# Altium schematic sheet Halloween2021.SchDoc(Halloween2021)
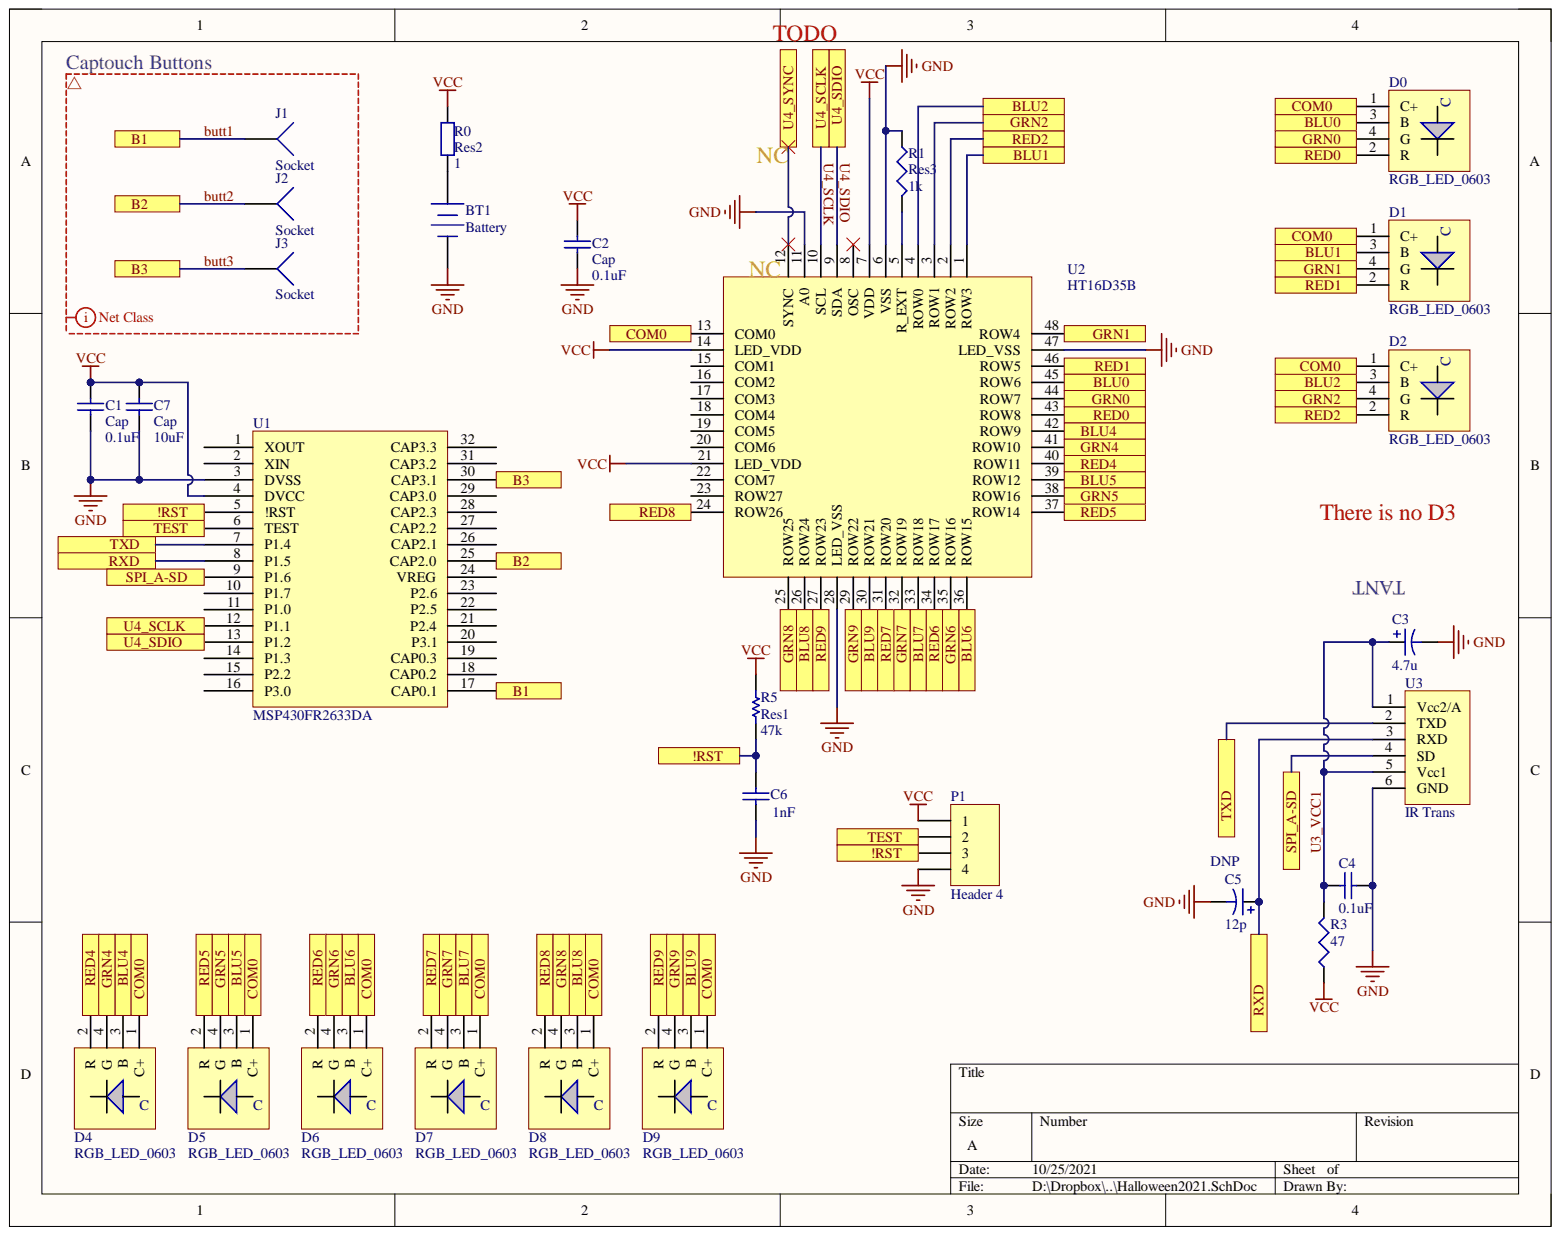
[diagram p0: Halloween2021.SchDoc]

## Parts
BT1  Battery  [Keystone 1050]
C1  Cap  value 0.1uF  [CAPC1608(0603)]
C2  Cap  value 0.1uF  [CAPC1608(0603)]
C3  value 4.7u  [CAPC1608(0603)]  (hidden comment: Cap Pol3)
C4  value 0.1uF  [CAPC1608(0603)]  (hidden comment: Cap)
C5  value 12p  [CAPC1608(0603)]  (hidden comment: Cap Pol3)
C6  value 1nF  [CAPC1608(0603)]
C7  Cap  value 10uF  [CAPC1608(0603)]
D0  RGB_LED_0603  [HQ19-2331BGRC]
D1  RGB_LED_0603  [HQ19-2331BGRC]
D2  RGB_LED_0603  [HQ19-2331BGRC]
D4  RGB_LED_0603  [HQ19-2331BGRC]
D5  RGB_LED_0603  [HQ19-2331BGRC]
D6  RGB_LED_0603  [HQ19-2331BGRC]
D7  RGB_LED_0603  [HQ19-2331BGRC]
D8  RGB_LED_0603  [HQ19-2331BGRC]
D9  RGB_LED_0603  [HQ19-2331BGRC]
J1  Socket  [captouch]
J2  Socket  [captouch]
J3  Socket  [captouch1.5mm]
P1  Header 4  [1x4 2mm Header]
R0  Res2  value 1  [RESC5025(2010)]
R1  Res3  value 1k  [RESC1608(0603)]
R3  value 47  [RESC1608(0603)]  (hidden comment: Res3)
R5  Res1  value 47k  [RESC1608(0603)]
U1  MSP430FR2633DA  [TSOP65P810X120-32N]
U2  HT16D35B  [TSQFP50P900X900X160-48N]
U3  IR Trans  [IR]

## Ports
!RST, B1, B2, B3, BLU0, BLU1, BLU2, BLU4, BLU5, BLU6, BLU7, BLU8, BLU9, COM0, GRN0, GRN1, GRN2, GRN4, GRN5, GRN6, GRN7, GRN8, GRN9, RED0, RED1, RED2, RED4, RED5, RED6, RED7, RED8, RED9, RXD, SPI_A-SD, TEST, TXD, U4_SCLK, U4_SDIO, U4_SYNC

## Nets
GND: BT1.2 C1.2 C2.1 C3.2 C4.2 C5.2 C6.1 C7.1 P1.4 R1.1 U1.3(DVSS) U2.6(VSS) U2.11(A0) U2.28(LED_VSS) U2.47(LED_VSS) U3.6(GND)
VCC: C1.1 C2.2 C7.2 P1.1 R0.2 R3.1 R5.1 U1.4(DVCC) U2.7(VDD) U2.14(LED_VDD) U2.21(LED_VDD)
!RST: C6.2 P1.3 R5.2 U1.5(!RST) ; port !RST
BLU0: D0.3(B) U2.45(ROW6) ; port BLU0
BLU1: D1.3(B) U2.1(ROW3) ; port BLU1
BLU2: D2.3(B) U2.4(ROW0) ; port BLU2
BLU4: D4.3(B) U2.42(ROW9) ; port BLU4
BLU5: D5.3(B) U2.39(ROW12) ; port BLU5
BLU6: D6.3(B) U2.36(ROW15) ; port BLU6
BLU7: D7.3(B) U2.33(ROW18) ; port BLU7
BLU8: D8.3(B) U2.26(ROW24) ; port BLU8
BLU9: D9.3(B) U2.30(ROW21) ; port BLU9
butt1: J1.1 U1.17(CAP0.1) ; port B1
butt2: J2.1 U1.25(CAP2.0) ; port B2
butt3: J3.1 U1.30(CAP3.1) ; port B3
COM0: D0.1(C+) D1.1(C+) D2.1(C+) D4.1(C+) D5.1(C+) D6.1(C+) D7.1(C+) D8.1(C+) D9.1(C+) U2.13(COM0) ; port COM0
GRN0: D0.4(G) U2.44(ROW7) ; port GRN0
GRN1: D1.4(G) U2.48(ROW4) ; port GRN1
GRN2: D2.4(G) U2.3(ROW1) ; port GRN2
GRN4: D4.4(G) U2.41(ROW10) ; port GRN4
GRN5: D5.4(G) U2.38(ROW16) ; port GRN5
GRN6: D6.4(G) U2.35(ROW16) ; port GRN6
GRN7: D7.4(G) U2.32(ROW19) ; port GRN7
GRN8: D8.4(G) U2.25(ROW25) ; port GRN8
GRN9: D9.4(G) U2.29(ROW22) ; port GRN9
RED0: D0.2(R) U2.43(ROW8) ; port RED0
RED1: D1.2(R) U2.46(ROW5) ; port RED1
RED2: D2.2(R) U2.2(ROW2) ; port RED2
RED4: D4.2(R) U2.40(ROW11) ; port RED4
RED5: D5.2(R) U2.37(ROW14) ; port RED5
RED6: D6.2(R) U2.34(ROW17) ; port RED6
RED7: D7.2(R) U2.31(ROW20) ; port RED7
RED8: D8.2(R) U2.24(ROW26) ; port RED8
RED9: D9.2(R) U2.27(ROW23) ; port RED9
RXD: C5.1 U1.8(P1.5) U3.3(RXD) ; port RXD
SPI_A-SD: U1.9(P1.6) U3.4(SD) ; port SPI_A-SD
TEST: P1.2 U1.6(TEST) ; port TEST
TXD: U1.7(P1.4) U3.2(TXD) ; port TXD
U3_VCC1: C3.1 C4.1 R3.2 U3.1(Vcc2/A) U3.5(Vcc1)
U4_SCLK: U1.12(P1.1) U2.10(SCL) ; port U4_SCLK
U4_SDIO: U1.13(P1.2) U2.9(SDA) ; port U4_SDIO
U4_SYNC: U2.12(SYNC) ; port U4_SYNC
NetBT1_1: BT1.1 R0.1
NetR1_2: R1.2 U2.5(R_EXT)

Not connected: U1 1(XOUT) 2(XIN) 10(P1.7) 11(P1.0) 14(P1.3) 15(P2.2) 16(P3.0) 18(CAP0.2) 19(CAP0.3) 20(P3.1) 21(P2.4) 22(P2.5) 23(P2.6) 24(VREG) 26(CAP2.1) 27(CAP2.2) 28(CAP2.3) 29(CAP3.0) 31(CAP3.2) 32(CAP3.3); U2 8(OSC) 15(COM1) 16(COM2) 17(COM3) 18(COM4) 19(COM5) 20(COM6) 22(COM7) 23(ROW27)

## Library data of the parts
BT1 = Battery: Multicell Battery; Component Kind: Standard; LatestRevisionDate: 17-Jul-2002; LatestRevisionNote: Re-released for DXP Platform.; Library Name: Miscellaneous Devices.IntLib; PackageDescription: Battery; 2 Leads; PackageReference: BAT-2; Published: 24-Mar-1999; Publisher: Altium Limited
C1 C2 C4 C6 C7 = Cap: Capacitor; Component Kind: Standard; LatestRevisionDate: 17-Jul-2002; LatestRevisionNote: Re-released for DXP Platform.; Library Name: Miscellaneous Devices.IntLib; PackageDescription: Radial Cap, Thru-Hole; 2 Leads; 0.3 in Pin Spacing; PackageReference: RAD-0.3; Published: 8-Jun-2000; Signal Integrity: Cap; Simulation: CAP; Publisher: Altium Limited
C3 C5 = Cap Pol3: Polarized Capacitor (Surface Mount); Component Kind: Standard; LatestRevisionDate: 29-May-2009; LatestRevisionNote: IPC-7351 Footprint Added.; Library Name: Miscellaneous Devices.IntLib; PackageDescription: Chip Capacitor; PackageReference: C0805; Published: 8-Jun-2000; Signal Integrity: Cap Pol; Simulation: CAP; Publisher: Altium Limited
P1 = Header 4: Header, 4-Pin; Component Kind: Standard; LatestRevisionDate: 17-Jul-2002; LatestRevisionNote: Re-released for DXP Platform.; Library Name: Miscellaneous Connectors.IntLib; Signal Integrity: Connector; Publisher: Altium Limited
R0 = Res2: Resistor; Component Kind: Standard; LatestRevisionDate: 17-Jul-2002; LatestRevisionNote: Re-released for DXP Platform.; Library Name: Miscellaneous Devices.IntLib; PackageReference: AXIAL-0.4; Published: 8-Jun-2000; Signal Integrity: Res; Simulation: RESISTOR; Publisher: Altium Limited
R1 R3 = Res3: Resistor; Component Kind: Standard; LatestRevisionDate: 29-May-2009; LatestRevisionNote: IPC-7351 Footprint Added.; Library Name: Miscellaneous Devices.IntLib; PackageDescription: Chip Resistor; PackageReference: J1-0603; Published: 8-Jun-2000; Signal Integrity: Res; Simulation: RESISTOR; Publisher: Altium Limited
R5 = Res1: Resistor; Component Kind: Standard; LatestRevisionDate: 17-Jul-2002; LatestRevisionNote: Re-released for DXP Platform.; Library Name: Miscellaneous Devices.IntLib; PackageDescription: Axial Device, Thru-Hole; 2 Leads; 0.3 in Pin Spacing; PackageReference: AXIAL-0.3; Published: 8-Jun-2000; Signal Integrity: Res; Simulation: RESISTOR; Publisher: Altium Limited
U2 = LED Controller QFP: Component Kind: Standard; Library Name: Halloween2021.SchLib
D0-D2 D4-D9 = RGB_LED_0603: Component Kind: Standard; Library Name: Halloween2021.SchLib
J1-J3 = Socket: Component Kind: Standard; Description: Socket; LatestRevisionDate: 17-Jul-2002; LatestRevisionNote: Re-released for DXP Platform.; Library Name: Miscellaneous Connectors.IntLib; Signal Integrity: Connector; Publisher: Altium Limited
U1 = MSP430FR2633DA: Component Kind: Standard; Library Name: Halloween2021.SchLib
U3 = IR Trans: Component Kind: Standard; Library Name: QC.SchLib
